# Altium schematic sheet Steering Wheel Interface Schmitt Inverters.SchDoc
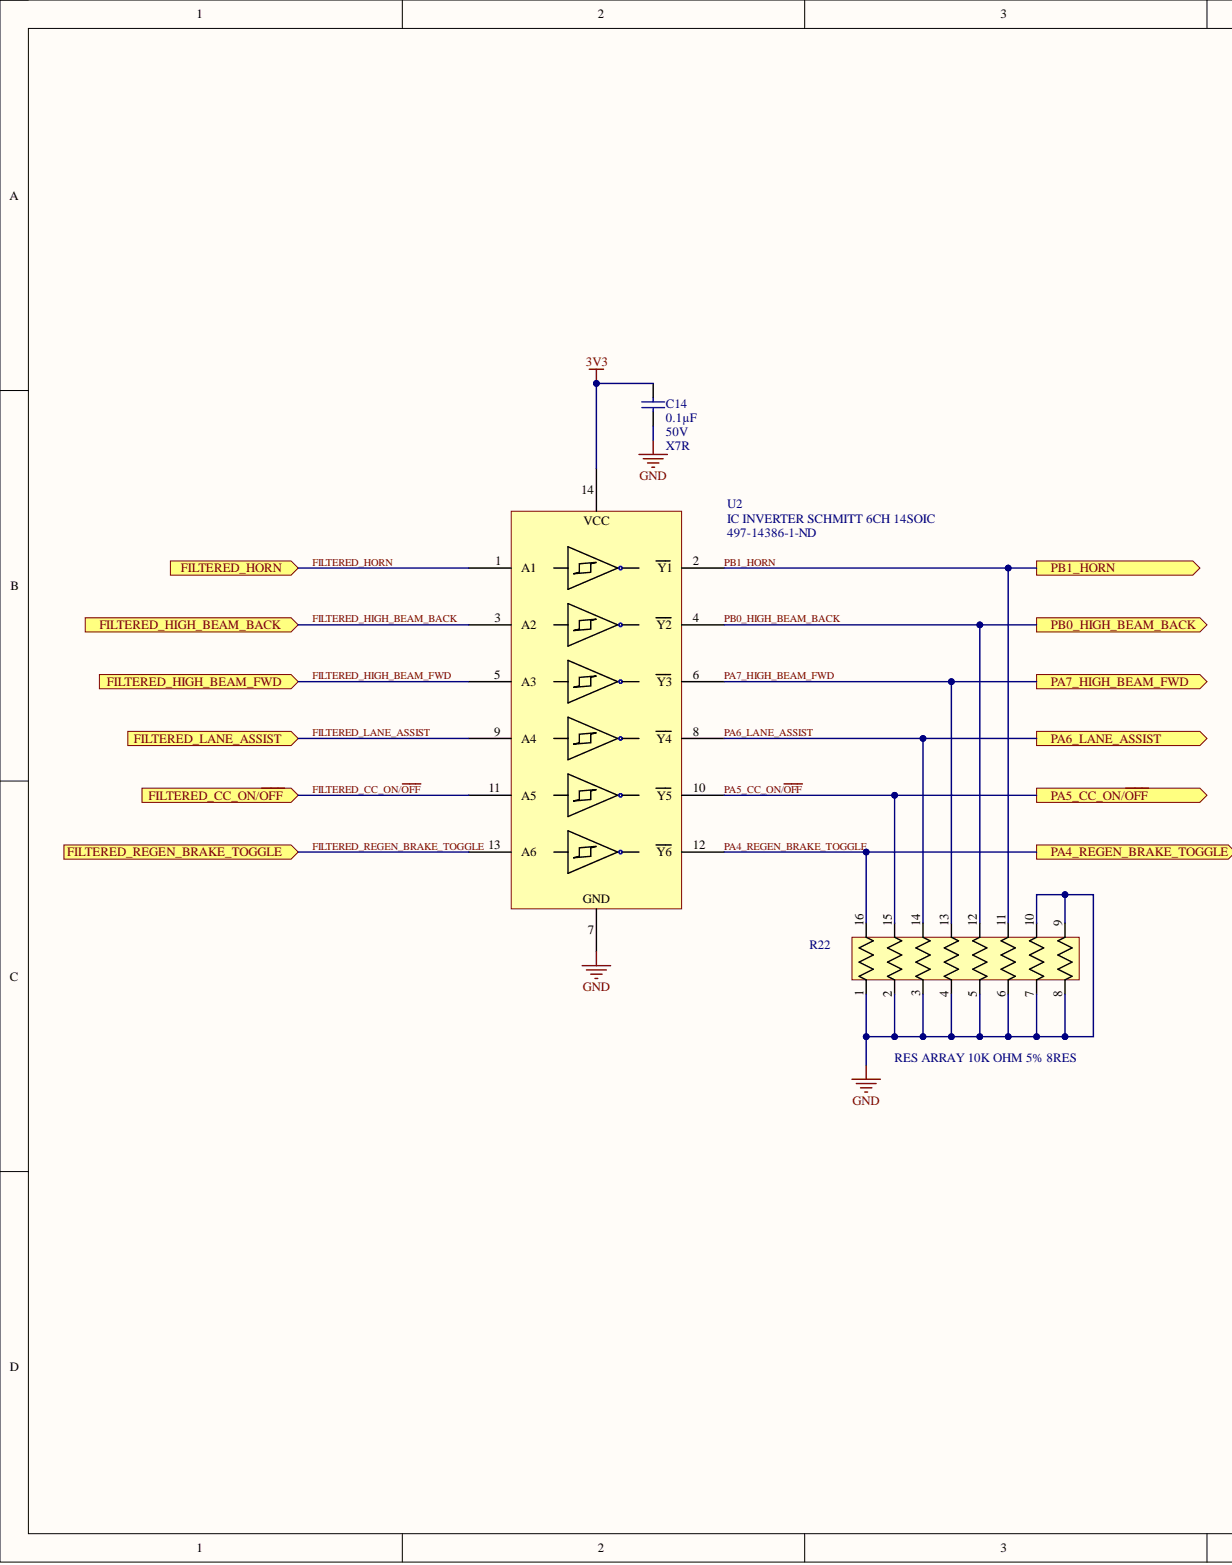
[diagram p0: Steering Wheel Interface Schmitt Inverters.SchDoc, left]
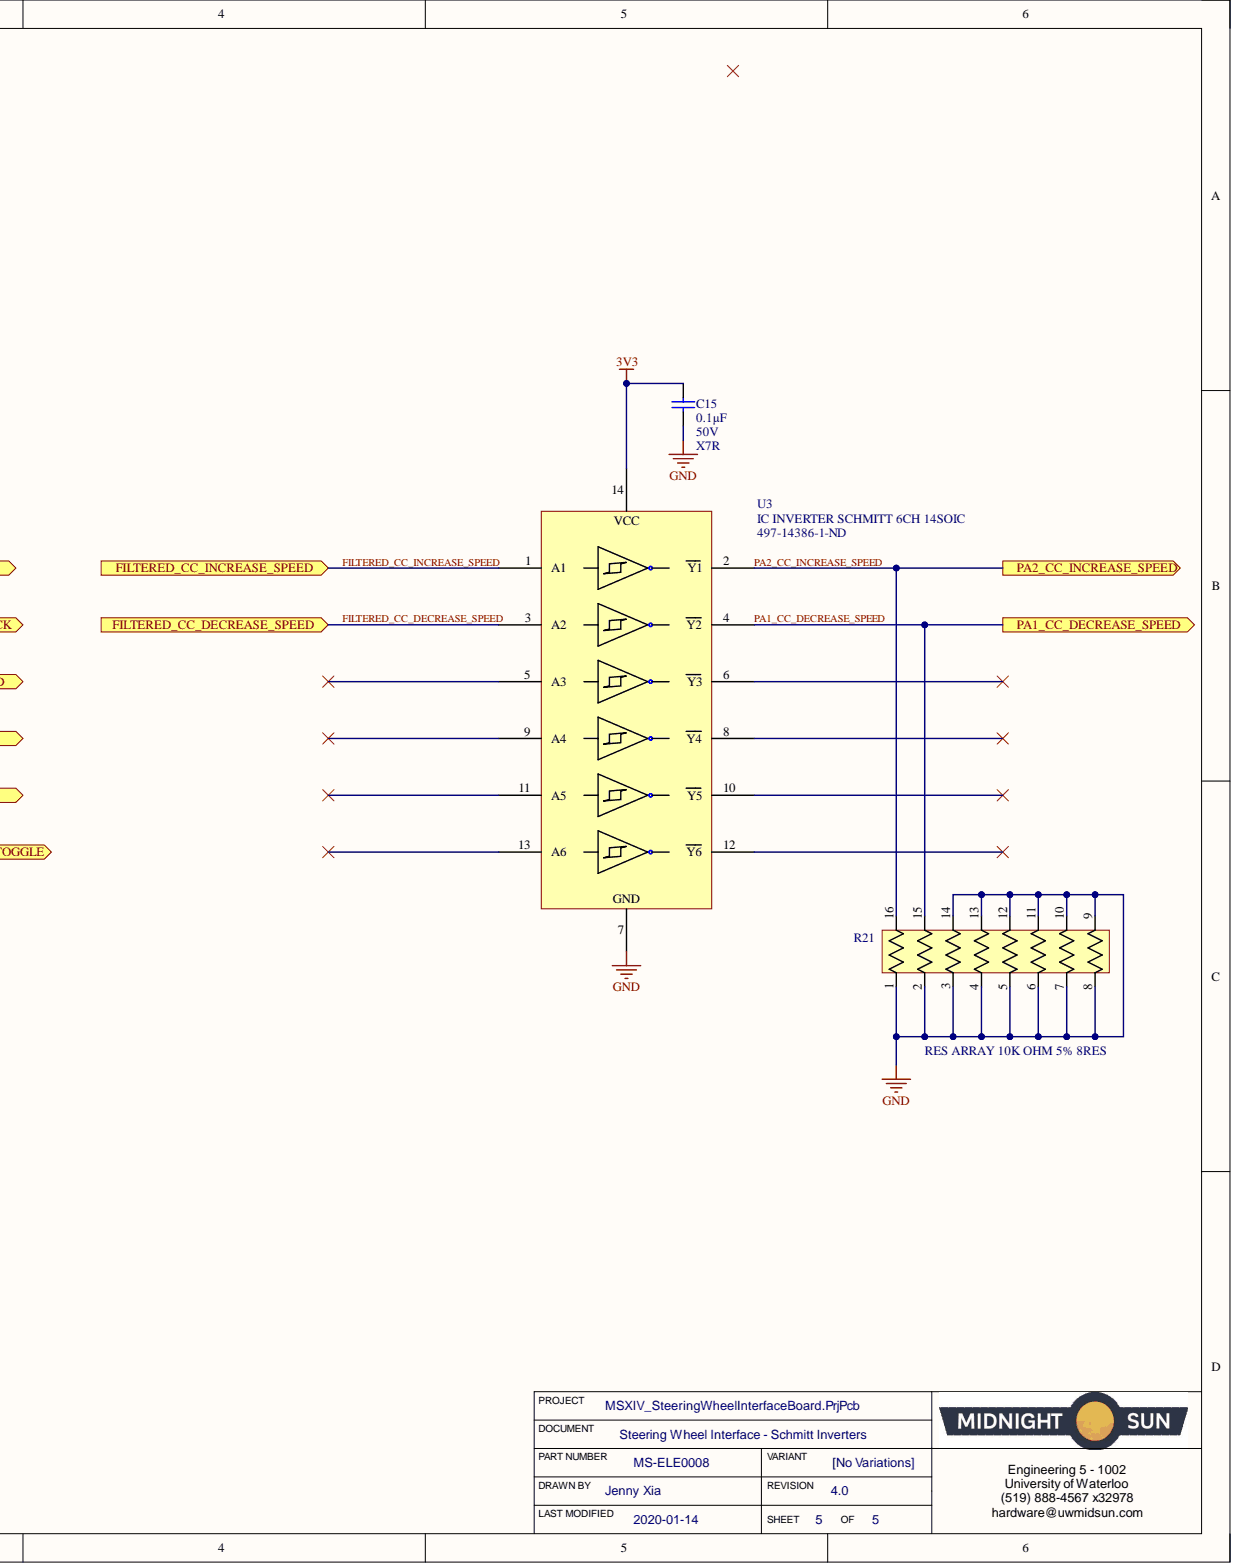
[diagram p1: Steering Wheel Interface Schmitt Inverters.SchDoc, right]

## Parts
C14  Capacitance: 0.1µF  Temperature Coefficient: X7R  Voltage: 50V  [CAP, 0603]  (hidden comment: CAP CER 0.1UF 50V 10% X7R 0603)
C15  Capacitance: 0.1µF  Temperature Coefficient: X7R  Voltage: 50V  [CAP, 0603]  (hidden comment: CAP CER 0.1UF 50V 10% X7R 0603)
R21  RES ARRAY 10K OHM 5% 8RES  [RES ARRAY, 8 POS 1506]
R22  RES ARRAY 10K OHM 5% 8RES  [RES ARRAY, 8 POS 1506]
U2  IC INVERTER SCHMITT 6CH 14SOIC  Supplier Part Number 1: 497-14386-1-ND  [IC INVERTER SCHMITT 6CH 14TSSOP]
U3  IC INVERTER SCHMITT 6CH 14SOIC  Supplier Part Number 1: 497-14386-1-ND  [IC INVERTER SCHMITT 6CH 14TSSOP]

## Ports
FILTERED_CC_DECREASE_SPEED, FILTERED_CC_INCREASE_SPEED, FILTERED_CC_ON/~{OFF}, FILTERED_HIGH_BEAM_BACK, FILTERED_HIGH_BEAM_FWD, FILTERED_HORN, FILTERED_LANE_ASSIST, FILTERED_REGEN_BRAKE_TOGGLE, PA1_CC_DECREASE_SPEED, PA2_CC_INCREASE_SPEED, PA4_REGEN_BRAKE_TOGGLE, PA5_CC_ON/~{OFF}, PA6_LANE_ASSIST, PA7_HIGH_BEAM_FWD, PB0_HIGH_BEAM_BACK, PB1_HORN

## Nets
3V3: C14.1 C15.1 U2.14 U3.14
GND: C14.2 C15.2 R21.1 R21.2 R21.3 R21.4 R21.5 R21.6 R21.7 R21.8 R21.9 R21.10 R21.11 R21.12 R21.13 R21.14 R22.1 R22.2 R22.3 R22.4 R22.5 R22.6 R22.7 R22.8 R22.9 R22.10 U2.7 U3.7
FILTERED_CC_DECREASE_SPEED: U3.3(A2) ; port FILTERED_CC_DECREASE_SPEED
FILTERED_CC_INCREASE_SPEED: U3.1(A1) ; port FILTERED_CC_INCREASE_SPEED
FILTERED_CC_ON/~{OFF}: U2.11(A5) ; port FILTERED_CC_ON/~{OFF}
FILTERED_HIGH_BEAM_BACK: U2.3(A2) ; port FILTERED_HIGH_BEAM_BACK
FILTERED_HIGH_BEAM_FWD: U2.5(A3) ; port FILTERED_HIGH_BEAM_FWD
FILTERED_HORN: U2.1(A1) ; port FILTERED_HORN
FILTERED_LANE_ASSIST: U2.9(A4) ; port FILTERED_LANE_ASSIST
FILTERED_REGEN_BRAKE_TOGGLE: U2.13(A6) ; port FILTERED_REGEN_BRAKE_TOGGLE
PA1_CC_DECREASE_SPEED: R21.15 U3.4(Y2) ; port PA1_CC_DECREASE_SPEED
PA2_CC_INCREASE_SPEED: R21.16 U3.2(Y1) ; port PA2_CC_INCREASE_SPEED
PA4_REGEN_BRAKE_TOGGLE: R22.16 U2.12(Y6) ; port PA4_REGEN_BRAKE_TOGGLE
PA5_CC_ON/~{OFF}: R22.15 U2.10(Y5) ; port PA5_CC_ON/~{OFF}
PA6_LANE_ASSIST: R22.14 U2.8(Y4) ; port PA6_LANE_ASSIST
PA7_HIGH_BEAM_FWD: R22.13 U2.6(Y3) ; port PA7_HIGH_BEAM_FWD
PB0_HIGH_BEAM_BACK: R22.12 U2.4(Y2) ; port PB0_HIGH_BEAM_BACK
PB1_HORN: R22.11 U2.2(Y1) ; port PB1_HORN

Not connected: U3 5(A3) 6(Y3) 8(Y4) 9(A4) 10(Y5) 11(A5) 12(Y6) 13(A6)

## Library data of the parts
C14 C15 = CAP CER 0.1UF 50V 10% X7R 0603: 0.10µF ±10% 50V Ceramic Capacitor X7R 0603 (1608 Metric); Manufacturer 1: AVX Corporation; Manufacturer Part Number 1: 06035C104KAT2A; Component Kind: Standard; ComponentLink1Description: http://datasheets.avx.com/X7RDielectric.pdf; ComponentLink1URL: http://datasheets.avx.com/X7RDielectric.pdf; Height - Seated (Max): -; Lead Spacing: -; Lead Style: -; Mounting Type: Surface Mount, MLCC; Operating Temperature: -55°C ~ 125°C; Package / Case: 0603 (1608 Metric); Packaging: Cut Tape (CT); Part Status: Active; Ratings: -; Size / Dimension: 0.063" L x 0.032" W (1.60mm x 0.81mm); Supplier 1: Digi-Key; Supplier Part Number 1: 478-5052-1-ND; Thickness (Max): 0.035" (0.90mm); Tolerance: ±10%; Library Name: Schematic Diagrams.SchLib
R21 R22 = RES ARRAY 10K OHM 5% 8RES EXB-2HV103JV: RES ARRAY SMD 10K 5% 62.5mW 1506; Manufacturer: Panasonic Electronic Components; C: Copyright (C) 2015 Accelerated Designs. All rights reserved; Circuit Type: Isolated; Temperature Coefficient: ±200ppm/°C; Vendor: Panasonic; Applications: Automotive AEC-Q200; Category: Resistors; Component Kind: Standard; ComponentLink1Description: https://industrial.panasonic.com/cdbs/www-data/pdf/AOC0000/AOC0000C14.pdf; ComponentLink1URL: https://industrial.panasonic.com/cdbs/www-data/pdf/AOC0000/AOC0000C14.pdf; Height - Seated (Max): 0.022" (0.55mm); Manufacturer Part Number: EXB-2HV103JV; Manufacturer_Part_Number: EXB-2HV103JV; Mounting Type: Surface Mount; Operating Temperature: -55°C ~ 125°C; Package / Case: 1506, Convex, Long Side Terminals; Packaging: Cut Tape (CT); Part Status: Active; Power Per Element: 62.5mW; Pricing 1: 1=0.43, 10=0.373, 25=0.2712, 50=0.1964, 100=0.1456, 250=0.11176, 500=0.08806, 1000=0.06096 (CAD); Resistance (Ohms): 10k; RoHS: RoHS Compliant; Size / Dimension: 0.150" L x 0.063" W (3.80mm x 1.60mm); Stock 1: 152779; Supplier 1: Digi-Key; Supplier Device Package: 1506; Supplier Part Number 1: Y1103CT-ND; Tolerance: ±5%; Value: Value; Copyright: Copyright (C) 2015 Accelerated Designs. All rights reserved; Library Name: Schematic Diagrams.SchLib
U2 U3 = IC INVERTER SCHMITT 6CH 14TSSOP: Inverter IC 6 Channel Schmitt Trigger 14-SOIC; Manufacturer: STMicroelectronics; Manufacturer Part Number: M74HC14YRM13TR; High Level Output Current: -5.2mA; Low Level Output Current: 5.2mA; Max Supply Voltage: 6V; Min Supply Voltage: 2V; Number of Circuits: 6; Propagation Delay: 21ns; Quiescent Current: 1uA; Turn-On Delay Time: 190ns; Case/Package: SO; Component Kind: Standard; ComponentLink1Description: Digikey; ComponentLink1URL: https://www.digikey.ca/product-detail/en/stmicroelectronics/M74HC14YTTR/497-14387-1-ND/4725844; ComponentLink2Description: http://www.st.com/content/ccc/resource/technical/document/datasheet/19/a3/52/42/dd/ab/4b/46/CD00000263.pdf/files/CD00000263.pdf/jcr:content/translations/en.CD00000263.pdf; ComponentLink2URL: http://www.st.com/content/ccc/resource/technical/document/datasheet/19/a3/52/42/dd/ab/4b/46/CD00000263.pdf/files/CD00000263.pdf/jcr:content/translations/en.CD00000263.pdf; ComponentLink3Description: http://www.st.com/st-web-ui/static/active/en/resource/technical/document/datasheet/CD00000263.pdf; ComponentLink3URL: http://www.st.com/st-web-ui/static/active/en/resource/technical/document/datasheet/CD00000263.pdf; ComponentLink4Description: http://www.st.com/content/ccc/resource/technical/document/datasheet/19/a3/52/42/dd/ab/4b/46/CD00000263.pdf/files/CD00000263.pdf/jcr:content/translations/en.CD00000263.pdf?src-supplier=Digi-Key; ComponentLink4URL: http://www.st.com/content/ccc/resource/technical/document/datasheet/19/a3/52/42/dd/ab/4b/46/CD00000263.pdf/files/CD00000263.pdf/jcr:content/translations/en.CD00000263.pdf?src-supplier=Digi-Key; ComponentLink5Description: http://www.st.com/st-web-ui/static/active/en/resource/technical/document/datasheet/CD00000263.pdf?src-supplier=Avnet; ComponentLink5URL: http://www.st.com/st-web-ui/static/active/en/resource/technical/document/datasheet/CD00000263.pdf?src-supplier=Avnet; Logic Function: Inverter; Max Operating Temperature: 125°C; Min Operating Temperature: -40°C; Mount: Surface Mount; Number of Elements: 6; Number of Inputs: 6; Number of Pins: 14; Package Quantity: 2500; Packaging: Tape and Reel; Pricing 1: 1 = 0.48000, 10 = 0.41300, 100 = 0.30860, 500 = 0.24246, 1000 = 0.18736 (USD); RoHS: Yes; Stock 1: 28888; Supplier 1: Digi-Key; Weight: 0.009143oz; Library Name: Schematic Diagrams.SchLib
